# Altium schematic sheet 2017_LEVI.SchDoc
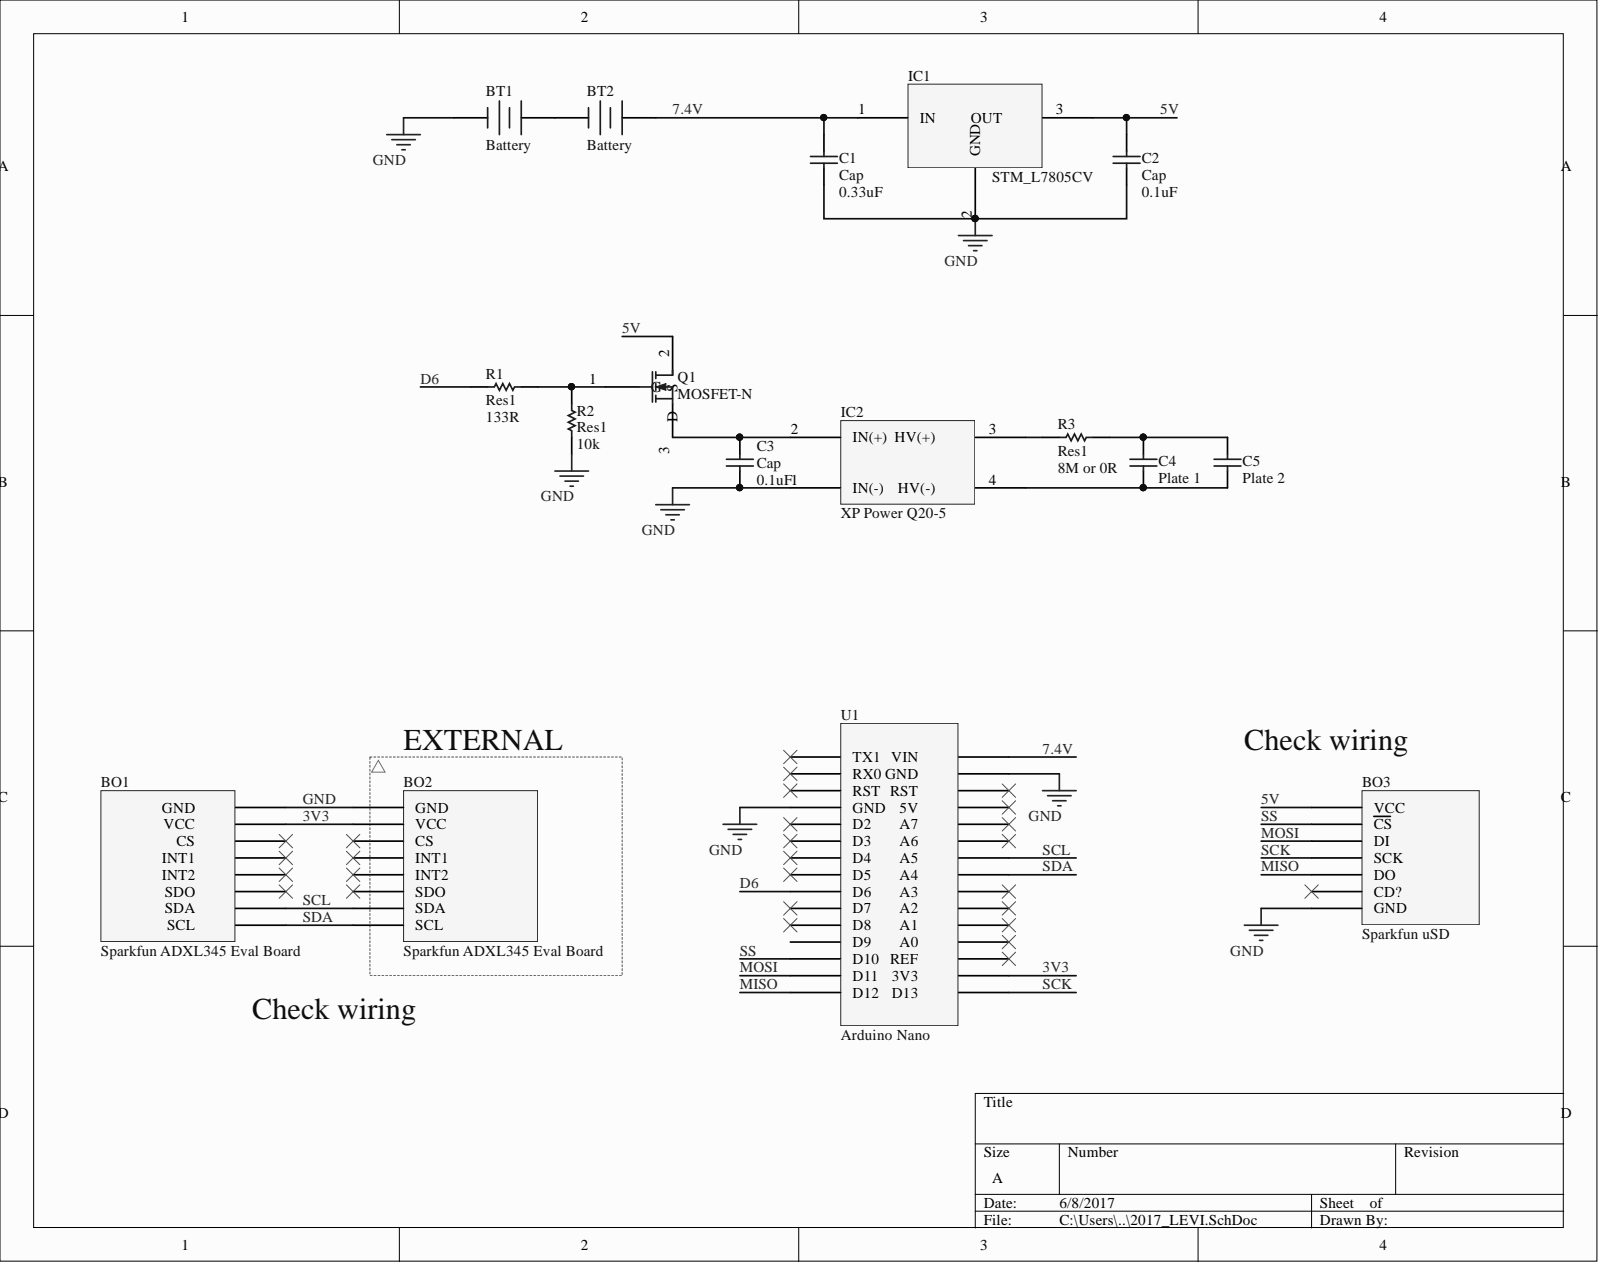
[diagram p0: 2017_LEVI.SchDoc]

## Parts
BO1  Sparkfun ADXL345 Eval Board
BO2  Sparkfun ADXL345 Eval Board
BO3  Sparkfun uSD
BT1  Battery  [BAT-2]
BT2  Battery  [BAT-2]
C1  Cap  value 0.33uF  [RAD-0.3]
C2  Cap  value 0.1uF  [RAD-0.3]
C3  Cap  value 0.1uF  [RAD-0.3]
C4  Plate 1  [RAD-0.3]
C5  Plate 2  [RAD-0.3]
IC1  STM_L7805CV
IC2  XP Power Q20-5
Q1  MOSFET-N  [E3]
R1  Res1  value 133R  [AXIAL-0.3]
R2  Res1  value 10k  [AXIAL-0.3]
R3  Res1  value 8M or 0R  [AXIAL-0.3]
U1  Arduino Nano

## Nets
3V3: BO1.VCC BO2.VCC U1.3V3
5V: BO3.VCC C2.2 IC1.3 Q1.2
7.4V: BT2.1 C1.2 IC1.1 U1.VIN
D6: R1.1 U1.D6
GND: BO1.GND BO2.GND BO3.GND BT1.2 C1.1 C2.1 C3.1 IC1.2 IC2.1(IN(-)) R2.1 U1.GND
MISO: BO3.DO U1.D12
MOSI: BO3.DI U1.D11
SCK: BO3.SCK U1.D13
SCL: BO1.SDA BO2.SDA U1.A5
SDA: BO1.SCL BO2.SCL U1.A4
SS: BO3.\C\S\ U1.D10
NetBT1_1: BT1.1 BT2.2
NetC3_2: C3.2 IC2.2(IN(+)) Q1.3
NetC4_1: C4.1 C5.1 IC2.4
NetC4_2: C4.2 C5.2 R3.2
NetIC2_3: IC2.3 R3.1
NetQ1_1: Q1.1 R1.2 R2.2

Not connected: BO1 CS INT1 INT2 SDO; BO2 CS INT1 INT2 SDO; BO3 CD?; U1 5V A0 A1 A2 A3 A6 A7 D2 D3 D4 D5 D7 D8 D9 REF RST RX0(RX0_GND) TX1

## Library data of the parts
BT1 BT2 = Battery: Multicell Battery; Component Kind: Standard; LatestRevisionDate: 17-Jul-2002; LatestRevisionNote: Re-released for DXP Platform.; Library Name: Miscellaneous Devices.IntLib; PackageDescription: Battery; 2 Leads; PackageReference: BAT-2; Published: 24-Mar-1999; Publisher: Altium Limited
C1-C5 = Cap: Capacitor; Component Kind: Standard; LatestRevisionDate: 17-Jul-2002; LatestRevisionNote: Re-released for DXP Platform.; Library Name: Miscellaneous Devices.IntLib; PackageDescription: Radial Cap, Thru-Hole; 2 Leads; 0.3 in Pin Spacing; PackageReference: RAD-0.3; Published: 8-Jun-2000; Signal Integrity: Cap; Simulation: CAP; Publisher: Altium Limited
Q1 = MOSFET-N: N-Channel MOSFET; Component Kind: Standard; LatestRevisionDate: 17-Jul-2002; LatestRevisionNote: Re-released for DXP Platform.; Library Name: Miscellaneous Devices.IntLib; PackageDescription: TO, Flat Index; 3 In-Line, Axial Leads; Body Dia. 4.6mm; Lead Dia. 0.5 mm (max); PackageReference: E3; PackageVersion: 1995/1996; Published: 8-Jun-2000; Simulation: NMOS; Publisher: Altium Limited
R1 R2 = Res1: Resistor; Component Kind: Standard; LatestRevisionDate: 17-Jul-2002; LatestRevisionNote: Re-released for DXP Platform.; Library Name: Miscellaneous Devices.IntLib; PackageDescription: Axial Device, Thru-Hole; 2 Leads; 0.3 in Pin Spacing; PackageReference: AXIAL-0.3; Published: 8-Jun-2000; Signal Integrity: Res; Simulation: RESISTOR; Publisher: Altium Limited
R3 = Res1: Resistor; Value: 8M or 0R; Component Kind: Standard; LatestRevisionDate: 17-Jul-2002; LatestRevisionNote: Re-released for DXP Platform.; Library Name: Miscellaneous Devices.IntLib; PackageDescription: Axial Device, Thru-Hole; 2 Leads; 0.3 in Pin Spacing; PackageReference: AXIAL-0.3; Published: 8-Jun-2000; Signal Integrity: Res; Simulation: RESISTOR; Publisher: Altium Limited
BO1 BO2 = Sparkfun ADXL345 Eval Board: Component Kind: Standard; Library Name: 2017_LEVI.SchLib
BO3 = Sparkfun uSD: Component Kind: Standard; Library Name: 2017_LEVI.SchLib
IC1 = STM_L7805CV: Component Kind: Standard; Library Name: 2017_LEVI.SchLib
IC2 = XP Power Q20-5: Component Kind: Standard; Library Name: 2017_LEVI.SchLib
U1 = Arduino Nano: Component Kind: Standard; Library Name: 2017_LEVI.SchLib
